# Altium schematic sheet AdvancedPowerBoard.SchDoc
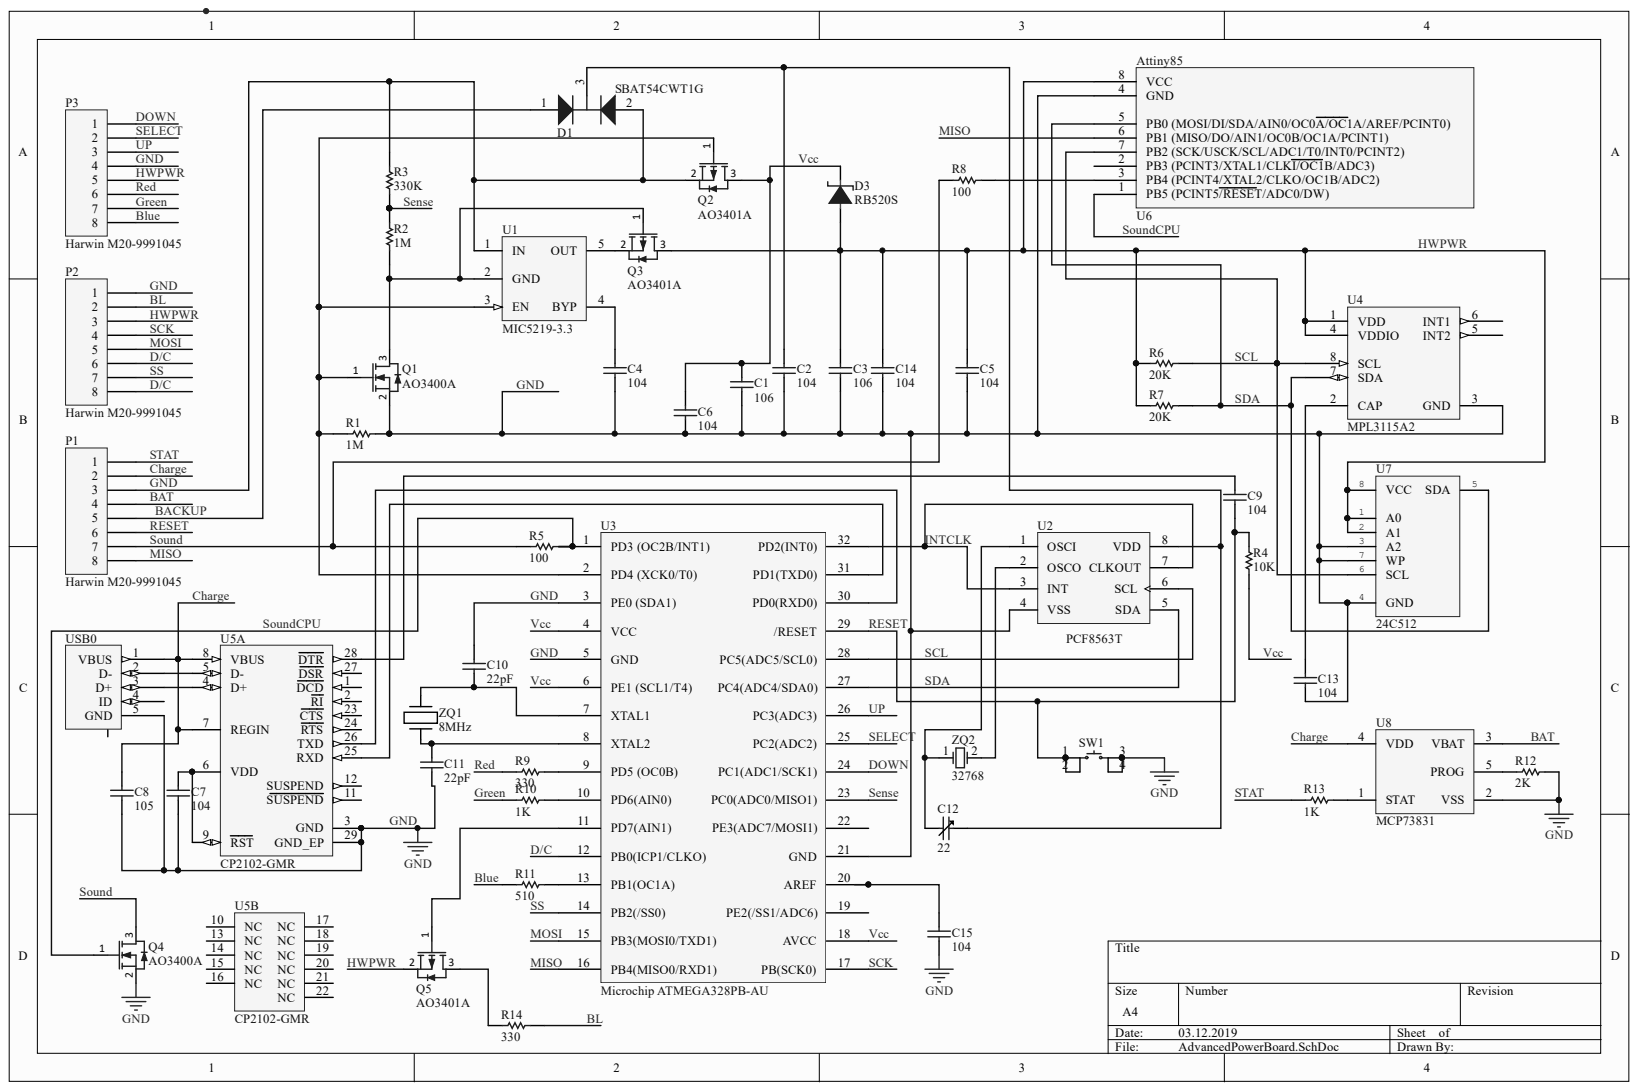
[diagram p0: AdvancedPowerBoard.SchDoc]

## Parts
C1  106  [CAPC3216X180X50ML20T25]
C2  104  [PCB-5tpsunaefwt9kh6vel8b-1]
C3  106  [CAPC3216X180X50ML20T25]
C4  104  [PCB-5tpsunaefwt9kh6vel8b-1]
C5  104  [PCB-5tpsunaefwt9kh6vel8b-1]
C6  104  [PCB-5tpsunaefwt9kh6vel8b-1]
C7  104  [PCB-5tpsunaefwt9kh6vel8b-1]
C8  105  [PCB-5tpsunaefwt9kh6vel8b-1]
C9  104  [PCB-5tpsunaefwt9kh6vel8b-1]
C10  22pF  [PCB-o7p1koxmr9wqz41gh50t-1]
C11  22pF  [PCB-o7p1koxmr9wqz41gh50t-1]
C12  22  [PCB-qc9yv0nqxg2xuwukllfy-1]
C13  104  [PCB-5tpsunaefwt9kh6vel8b-1]
C14  104  [PCB-5tpsunaefwt9kh6vel8b-1]
C15  104  [PCB-5tpsunaefwt9kh6vel8b-1]
D1  SBAT54CWT1G  [ONSC-SOT-323-3-419-04_V]
D3  RB520S  [ONSC-SOD-523-2-502-01_V]
P1  Harwin M20-9991045  [PCB-5069yp845a99ca3lzjl2-1]
P2  Harwin M20-9991045  [PCB-5069yp845a99ca3lzjl2-1]
P3  Harwin M20-9991045  [PCB-5069yp845a99ca3lzjl2-1]
Q1  AO3400A  [PCB-832305-1]
Q2  AO3401A  [PCB-832298-1]
Q3  AO3401A  [PCB-832298-1]
Q4  AO3400A  [PCB-832305-1]
Q5  AO3401A  [PCB-832298-1]
R1  1M  [PCB-tf0m4f9deqts1r6cqpkj-1]
R2  1M  [PCB-tf0m4f9deqts1r6cqpkj-1]
R3  330K  [PCB-tf0m4f9deqts1r6cqpkj-1]
R4  10K  [PCB-tf0m4f9deqts1r6cqpkj-1]
R5  100  [PCB-tf0m4f9deqts1r6cqpkj-1]
R6  20K  [PCB-tf0m4f9deqts1r6cqpkj-1]
R7  20K  [PCB-tf0m4f9deqts1r6cqpkj-1]
R8  100  [PCB-tf0m4f9deqts1r6cqpkj-1]
R9  330  [PCB-tf0m4f9deqts1r6cqpkj-1]
R10  1K  [PCB-tf0m4f9deqts1r6cqpkj-1]
R11  510  [PCB-tf0m4f9deqts1r6cqpkj-1]
R12  2K  [PCB-tf0m4f9deqts1r6cqpkj-1]
R13  1K  [PCB-tf0m4f9deqts1r6cqpkj-1]
R14  330  [PCB-tf0m4f9deqts1r6cqpkj-1]
SW1  (no value)  [PCB-xogvx2jywf054ekw5prm-1]
U1  MIC5219-3.3  [SOT23-5L]
U2  PCF8563T  [PCB-mkdigkdyo5adg2mbgdfg-1]
U3  Microchip ATMEGA328PB-AU  Manufacturer Part Number: ATMEGA328PB-AU  [PCBComponent_1]
U4  MPL3115A2  [PCB-okdswjo58vr2mv39jdbf-1]
U5  CP2102-GMR  [QFN-28]  (parts A,B)
U6  Attiny85  [PCBComponent_1]
U7  24C512  [SOIC127P600X175-8N]
U8  MCP73831  [PCB-72jkpk30gdzgbvntf0xr-1]
USB0  Hirose ZX62R-B-5P  [PCB-kuo5gykd7jvbo0o0jro3-1]  (value not drawn)
ZQ1  8MHz  [PCB-goeqo0oliip49mp0922q-1]
ZQ2  32768  [PCB-716svxpcg0vvf3fg009p-1]

## Nets
BACKUP: D1.1 P1.5
BAT: P1.4 U8.3(VBAT)
BL: P2.2 R14.2
BLUE: P3.8 R11.1
CHARGE: C8.1 P1.2 U5.7(REGIN) U5.8(VBUS) U8.4(VDD) USB0.1(VBUS)
D/C: P2.6 P2.8 U3.12(PB0(ICP1/CLKO))
DOWN: P3.1 U3.24(PC1(ADC1/SCK1))
GND: C1.1 C2.2 C3.1 C4.2 C5.2 C6.2 C7.2 C8.2 C10.1 C11.2 C13.2 C14.2 C15.2 D1.2 P1.3 P2.1 P3.4 Q1.2 Q2.2 Q4.2 R1.2 R3.1 R12.2 SW1.3 SW1.4 U1.1(IN) U2.4(VSS) U3.3(PE0_(SDA1)) U3.5(GND) U3.21(GND) U4.3(GND) U5.3(GND) U5.29(GND_EP) U6.4(GND) U7.3(A2) U7.4(GND) U7.7(WP) U8.2(VSS) USB0.5(GND)
GREEN: P3.7 R10.1
HWPWR: C3.2 C5.1 C14.1 D3.2 P2.3 P3.5 Q3.3 Q5.2 R6.1 R7.1 U4.1(VDD) U4.4(VDDIO) U6.8(VCC) U7.1(A0) U7.2(A1) U7.8(VCC)
INTCLK: U2.3(INT) U2.7(CLKOUT) U3.32(PD2(INT0))
MISO: P1.8 U3.16(PB4(MISO0/RXD1)) U6.6(PB1_(MISO/DO/AIN1/OC0B/OC1A/PCINT1))
MOSI: P2.5 U3.15(PB3(MOSI0/TXD1))
RED: P3.6 R9.1
RESET: C9.2 P1.6 R4.1 SW1.1 SW1.2 U3.29(/RESET)
SCK: P2.4 U3.17(PB(SCK0))
SCL: R6.2 U2.6(SCL) U3.28(PC5(ADC5/SCL0)) U4.8(SCL) U6.7(PB2_(SCK/USCK/SCL/ADC1/T0/INT0/PCINT2)) U7.6(SCL)
SDA: R7.2 U2.5(SDA) U3.27(PC4(ADC4/SDA0)) U4.7(SDA) U6.5(PB0_(MOSI/DI/SDA/AIN0/OC0A/OC1A/AREF/PCINT0)) U7.5(SDA)
SELECT: P3.2 U3.25(PC2(ADC2))
SENSE: R2.1 R3.2 U3.23(PC0(ADC0/MISO1))
SOUND: P1.7 Q4.3 R5.1 R8.1
SOUNDCPU: Q4.1 R5.2 U3.1(PD3_(OC2B/INT1)) U6.1(PB5_(PCINT5/RESET/ADC0/DW))
SS: P2.7 U3.14(PB2(/SS0))
STAT: P1.1 R13.1
UP: P3.3 U3.26(PC3(ADC3))
VCC: C1.2 C6.1 D3.1 Q2.3 R4.2 U3.4(VCC) U3.6(PE1_(SCL1/T4)) U3.18(AVCC)
NetC2_1: C2.1 C12.2 D1.3 U2.8(VDD)
NetC4_1: C4.1 U1.4(BYP)
NetC7_1: C7.1 U5.6(VDD) U5.9(RST)
NetC9_1: C9.1 U5.28(DTR)
NetC10_2: C10.2 U3.7(XTAL1) ZQ1.2
NetC11_1: C11.1 U3.8(XTAL2) ZQ1.1
NetC12_1: C12.1 U2.1(OSCI) ZQ2.1
NetC13_1: C13.1 U4.2(CAP)
NetC15_1: C15.1 U3.20(AREF)
NetQ1_1: Q1.1 Q2.1 R1.1 U1.3(EN) U3.2(PD4_(XCK0/T0))
NetQ1_3: Q1.3 Q3.1 R2.2 U1.2(GND)
NetQ3_2: Q3.2 U1.5(OUT)
NetQ5_1: Q5.1 U3.11(PD7(AIN1))
NetQ5_3: Q5.3 R14.1
NetR8_2: R8.2 U6.3(PB4_(PCINT4/XTAL2/CLKO/OC1B/ADC2))
NetR9_2: R9.2 U3.9(PD5_(OC0B))
NetR10_2: R10.2 U3.10(PD6(AIN0))
NetR11_2: R11.2 U3.13(PB1(OC1A))
NetR12_1: R12.1 U8.5(PROG)
NetR13_2: R13.2 U8.1(STAT)
NetU2_2: U2.2(OSCO) ZQ2.2
NetU3_30: U3.30(PD0(RXD0)) U5.26(TXD)
NetU3_31: U3.31(PD1(TXD0)) U5.25(RXD)
NetU5_4: U5.4(D+) USB0.3(D+)
NetU5_5: U5.5(D-) USB0.2(D-)

Not connected: U3 19(PE2(/SS1/ADC6)) 22(PE3(ADC7/MOSI1)); U4 5(INT2) 6(INT1); U5 1(DCD) 2(RI) 10(NC) 11(SUSPEND) 12(SUSPEND) 13(NC) 14(NC) 15(NC) 16(NC) 17(NC) 18(NC) 19(NC) 20(NC) 21(NC) 22(NC) 23(CTS) 24(RTS) 27(DSR); U6 2(PB3_(PCINT3/XTAL1/CLKI/OC1B/ADC3)); USB0 4(ID) 6

## Library data of the parts
C1 C3 = CMP-127283-3: Multilayer Ceramic Capacitor, 10 uF, +/- 10%, 16 V, 1206 (3216 Metric), -55 to 125 degC, RoHS, Tape and Reel; Manufacturer Part Number: 1206YC106KAT2A; Capacitance: 10.0 µF; Dielectric Characteristic: X7R; Lead-Free Status: Lead Free; Voltage Rating (DC): 16.0 V; Capacitance Tolerance: ±10%; Case/Package: 1206; Component Kind: Standard; DatasheetVersion: Jul-16; Library Name: CommunityVault; Mounting Style: Surface Mount; Number of Pins: 2; OctopartID: f5623eedd0af2aa5; Octopart Url: http://octopart.com/1206yc106kat2a-avx-42270891; PackageDescription: 2-Pin Surface Mount Device, Body 3.2 x 1.6 mm; PackageReference: 1206; Packaging: Cut Tape (CT), Tape & Reel (TR); REACH SVHC Compliance: No SVHC; RoHS: Compliant; Seller Landing  Url: https://octopart-clicks.com/click/track?country=US&ak=8157f166&sig=00f688f&sid=1106&ppid=42270891&vpid=116186707&ct=offers
C2 C4-C9 C13-C15 = CMP-85534e2cc7555a94-1: Cap Ceramic 0.1uF 16V X7R 10% SMD 0603 125C T/R; Manufacturer Part Number: 3-1676869-7; ELV Compliant: Compliant; Voltage Rating (DC): 16.0 V; Component Kind: Standard; Dielectric Characteristic: X7R; Library Name: CommunityVault; Lifecycle Status: Not Listed by Manufacturer; OctopartID: 85534e2cc7555a94
C10 C11 = CMP-5839914dedd787fe-1: CL10 Series 22 pF 50V ±5 % Tolerance C0G SMT Multilayer Ceramic Capacitor; Manufacturer: Samsung; Manufacturer Part Number: CL10C220JB8NNNC; Dielectric Characteristic: C0G/NP0; Component Kind: Standard; Library Name: CommunityVault; Mounting Style: Surface Mount; Octopart URL: http://octopart.com/cl10c220jb8nnnc-samsung-8294536
C12 = CMP-26b5efbc6256f972-1: Cap Trimmer Ceramic 6.5/30pF 100V N1200±500 ppm/°C 0% to 50% Top Adj 85°C T/R; Manufacturer Part Number: TZC3P300A110R00; Capacitance: 30.0 pF (max); Color: Green; Lead-Free Status: Lead Free; Temperature Coefficient: -500 ppm/°C to 500 ppm/°C; Component Kind: Standard; Library Name: CommunityVault; Mounting Style: Surface Mount; OctopartID: 26b5efbc6256f972; Octopart URL: https://octopart.com/tzc3p300a110r00-murata-221573; Operating Temperature: 85.0 °C (max); Packaging: Cut Tape (CT); REACH SVHC Compliance: No SVHC; RoHS: Compliant; Size-Height: 1.60 mm; Size-Length: 4.50 mm; Size-Width: 3.20 mm; Voltage Rating (DC): 100 V
D1 = CMP-813023-3: Dual Series Cathode Schottky Barrier Diodes, 3-Pin SOT-323, Pb-Free, Tape and Reel; Lead-Free Status: Lead Free; Component Kind: Standard; Halogen Free Status: Halogen Free; Library Name: CommunityVault; Lifecycle Status: Active; Manufacturer Part Number: SBAT54CWT1G; OctopartID: 4ab382e8d3a89574; Octopart Url: https://octopart.com/sbat54cwt1g-on+semiconductor-22133885; RoHS: Compliant
D3 = CMP-4964408-4: Schottky Barrier Diode, 2-Pin SOD-523, Pb-Free, Tape and Reel; Manufacturer Part Number: RB520S30T1G; Current Rating: 0.2 A; Lead-Free Status: Lead Free; Polarity: Standard; Voltage Rating (DC): 30.0 V; Case/Package: SOD-523; Component Kind: Standard; Library Name: CommunityVault; Lifecycle Status: Active; Mounting Style: Surface Mount; Number of Pins: 2; OctopartID: 89a140aeb8bc5666; Octopart Url: https://octopart.com/rb520s30t1g-on+semiconductor-332923; Packaging: Cut Tape (CT); REACH SVHC Compliance: No SVHC; RoHS: Compliant
P1-P3 = CMP-967a5f748d4649bd-3: Header Connector, PCB Mount, RECEPT, 8 Contacts, PIN, 0.1 Pitch, R ANGLE PC TAIL Terminal; Number of Contacts: 8; Voltage Rating (DC): 250 V; Component Kind: Standard; Contact Plating: Tin; Flammability Rating: UL 94 V-0; Library Name: CommunityVault; Lifecycle Status: Active; Mounting Style: Solder, Through Hole; Number of Positions: 8; Number of Rows: 1; Octopart URL: https://octopart.com/22-28-8081-molex-278106; Operating Temperature: 75.0 °C (max); Orientation: Right Angle; Size-Height: 2.49 mm; Size-Length: 20.3 mm; Size-Width: 2.29 mm
Q1 Q4 = CMP-832305-6: MOSFET N-CH 30V 5.7A SOT23; Component Kind: Standard; Library Name: CommunityVault
Q2 Q3 Q5 = CMP-832298-6: MOSFET P-CH 30V 4A SOT23; Lead-Free Status: Lead Free; Component Kind: Standard; Library Name: CommunityVault; Manufacturer Part Number: AO3401A; OctopartID: b3d67a74a8336c11; Octopart Url: https://octopart.com/ao3401a-alpha+%26+omega+semiconductor-11026051; RoHS: Compliant
R1-R14 = CMP-1858798-3: MCT 0603 Series 0603 0.125 W 1000 kOhms 1 % 50 PPM Precision Flat Chip Resistor; Manufacturer Part Number: MCT06030C1004FP500; Lead-Free Status: Lead Free; Power Rating: 100 mW; Resistance: 1.00 MΩ; Resistance Tolerance: ±1%; Voltage Rating (DC): 75.0 V; Case/Package: 0603, 1608; Component Kind: Standard; Library Name: CommunityVault; Mounting Style: Surface Mount; OctopartID: b6077c40ba4e69c0; Octopart URL: https://octopart.com/mct06030c1004fp500-vishay-55388463; Packaging: Cut Tape (CT); REACH SVHC Compliance: No SVHC; RoHS: Compliant; Size-Height: 450 µm; Size-Width: 850 µm
SW1 = CMP-74febde4724cab39-1: Switch Tactile N.O. SPST Button Gull Wing 0.05A 12VDC 0.98N SMD T/R; Lead-Free Status: Lead Free; Component Kind: Standard; Contacts Type: SPST; Library Name: CommunityVault; Mounting Style: Surface Mount; OctopartID: 74febde4724cab39; REACH SVHC Compliance: No SVHC; RoHS: Compliant
U1 = CMP-1f32d63031e4c0f5-3: asd; Manufacturer Part Number: MIC5219-3.3YM5 TR; Input Voltage (DC): 12.0 V (max); Lead-Free Status: Lead Free; Output Current: 500 mA; Case/Package: SOT-23; Component Kind: Standard; Library Name: CommunityVault; Lifecycle Status: Not Listed by Manufacturer; Mounting Style: Surface Mount; Number of Pins: 5; OctopartID: 1f32d63031e4c0f5; Octopart URL: http://octopart.com/mic5219-3.3ym5+tr-micrel-527280; Packaging: Cut Tape (CT), Tape & Reel (TR); REACH SVHC Compliance: No SVHC; RoHS: Compliant
U2 = CMP-ea9a22bb0207355d-5: PCF8563 Series 1.8 - 5.5 V Real Time Clock / Calendar - SOIC-8; Manufacturer Part Number: PCF8563T/5,518; Lead-Free Status: Lead Free; Component Kind: Standard; Library Name: CommunityVault; Lifecycle Status: Active; OctopartID: ea9a22bb0207355d; Octopart URL: http://octopart.com/pcf8563t%2F5%2C518-nxp+semiconductors-19647995; RoHS: Compliant
U3 = CMP-216eecc682ecfea9-2: 8-bit AVR® RISC-based microcontroller (MCU) with picoPower® technology; Component Kind: Standard; Library Name: CommunityVault; Octopart URL: https://octopart.com/atmega328pb-au-microchip-77760228
U4 = CMP-1172243-7: Pressure Sensor 7.25 PSI ~ 15.95 PSI (50 kPa ~ 110 kPa) Absolute 24 b 8-TLGA; Manufacturer: Freescale Semiconductor - NXP; Vendor Part Number: MPL3115A2-ND; Accuracy: ±0.058 PSI (±0.4 kPa); Maximum Pressure: 72.52 PSI (500 kPa); Output: 24 b; Output Type: I²C; Port Style: No Port; Vendor: Digikey; Voltage - Supply: 1.95 V ~ 3.6 V; Category: Sensors, Transducers; Component Kind: Standard; Cost: 3.04; Family: Pressure Sensors, Transducers; Features: Temperature Compensated; HelpURL: http://cache.freescale.com/files/sensors/doc/data_sheet/MPL3115A2.pdf; Library Name: CommunityVault; Manufacturer Part Number: MPL3115A2; OctopartID: 4c5f84616c529398; Octopart URL: http://octopart.com/mpl3115a2-freescale+semiconductor-20186475; Operating Pressure: 7.25 PSI ~ 15.95 PSI (50 kPa ~ 110 kPa); Operating Temperature: -40°C ~ 85°C; Package / Case: 8-TLGA; Pressure Typ: Absolute; Supplier Device Package: 8-LGA; Termination Style: PCB
U5 = CMP-1313603-12: USB Interface IC USB to UART Bridge QFN-28; Component Kind: Standard; Library Name: CommunityVault
U6 = CMP-1208391-4: MCU 8-bit ATtiny AVR RISC 8KB Flash 3.3V/5V 8-Pin SOIC EIAJ T/R; Manufacturer Part Number: ATTINY85-20SUR; Clock Speed: 20.0 MHz; Lead-Free Status: Lead Free; RAM Memory Size: 524288 B; Component Kind: Standard; Library Name: CommunityVault; Lifecycle Status: Not Listed by Manufacturer; Mounting Style: Surface Mount; OctopartID: ecab210346d1336c; Octopart URL: https://octopart.com/attiny85-20sur-microchip-77762332; Packaging: Cut Tape (CT); RoHS: Compliant
U7 = CMP-1168981-2: EEPROM, SERIAL, 512K (64KX8), 8SOIC; Manufacturer Part Number: AT24C512C-SSHD-B; Clock Speed: 1000000.0 Hz; Lead-Free Status: Lead Free; Memory Size: 64000 B; Component Kind: Standard; Library Name: CommunityVault; Number of Pins: 8; OctopartID: 92b25910cf4e4d75; Octopart Url: https://octopart.com/at24c512c-sshd-b-atmel-44595354; REACH SVHC Compliance: No SVHC; RoHS: Compliant
U8 = CMP-1156499-6: Microchip MCP73831T-2DCI/OT; Battery Charge Controller; 500mA; 5-Pin SOT-23; Input Voltage (DC): 3.75 V (min), 6.00 V (max); Supply Voltage (DC): 6.00 V (max); Case/Package: SOT-23; Component Kind: Standard; Library Name: CommunityVault; Lifecycle Status: Active; Manufacturer Part Number: MCP73831T-2DCI/OT; OctopartID: e9f820f4bfe0363f; Octopart URL: https://octopart.com/mcp73831t-2dci%2Fot-microchip-422782; Operating Temperature: 125 °C (max); Packaging: Cut Tape (CT), Tape & Reel (TR)
USB0 = CMP-d224198bdd290de3-7: Conn Micro USB 2.0 Type B RCP 5 POS 0.65mm Solder RA SMD 5 Terminal 1 Port T/R; Gender: Female; Lead-Free Status: Lead Free; Number of Contacts: 5; Component Kind: Standard; Contact Material: Copper; Contact Plating: Gold; Library Name: CommunityVault; Manufacturer Part Number: ZX62R-B-5P; Mounting Style: Surface Mount; OctopartID: d224198bdd290de3; Octopart URL: http://octopart.com/zx62r-b-5p-hirose-44378731; Orientation: Right Angle; Packaging: Cut Tape (CT); REACH SVHC Compliance: No SVHC; RoHS: Compliant
ZQ1 = CMP-783581-12: Manufacturer Part Number: ABM3-8.000MHZ-D2Y-T; Equivalent Series Resistance (ESR): 500 Ω; Frequency: 8.00 MHz; Component Kind: Standard; Description: 8Mhz; Library Name: CommunityVault; Mounting Style: Surface Mount; Number of Pins: 2; OctopartID: 025fca493e8add98; Packaging: Cut Tape (CT); REACH SVHC Compliance: Contains SVHC
ZQ2 = CMP-3793991-24: ABS07 Series 32.768 kHz ±20 ppm 12.5 pF -40 to +85 °C SMT Low Profile Crystal; Component Kind: Standard; Library Name: CommunityVault
